# Altium schematic sheet 300_AUX.SchDoc
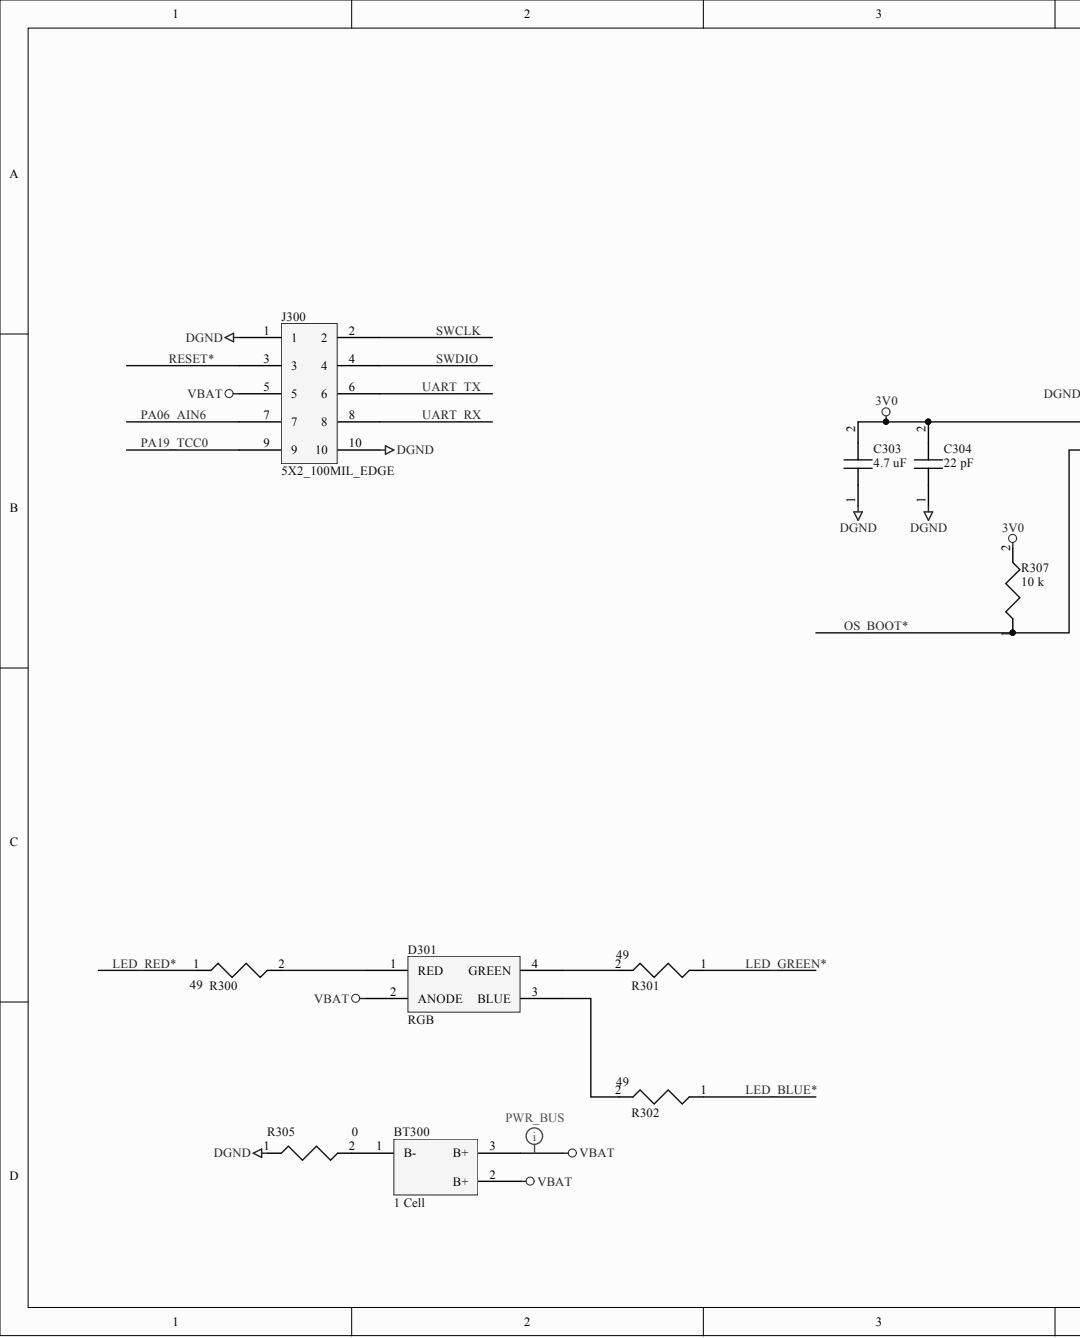
[diagram p0: 300_AUX.SchDoc, left]
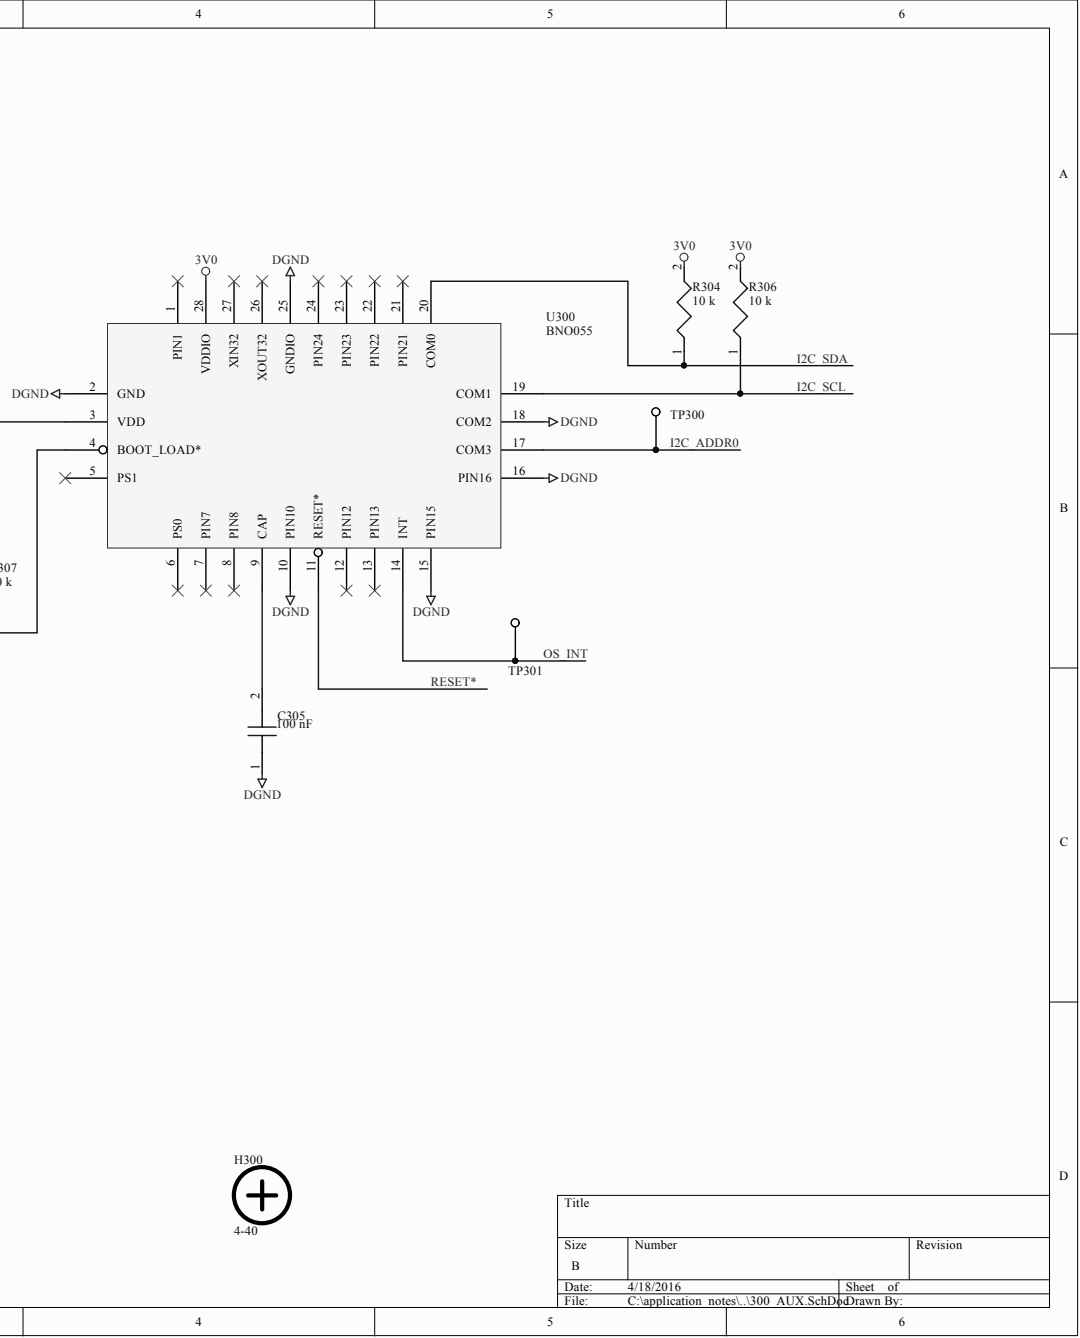
[diagram p1: 300_AUX.SchDoc, right]

## Parts
BT300  1 Cell  [CR2032_HOLDER]
C303  4.7 uF  [CAPC1608N]
C304  22 pF  [CAPC1005N]
C305  100 nF  [CAPC1005N]
D301  RGB  [CLVA-FKB]
H300  4-40  [SCREW_4-40]  (no BOM)
J300  5X2_100MIL_EDGE  [5X2_100_EDGE]
R300  49  [RESC1005N]
R301  49  [RESC1005N]
R302  49  [RESC1005N]
R304  10 k  [RESC1005N]
R305  0  [RESC1005N]  (value not drawn)
R306  10 k  [RESC1005N]
R307  10 k  [RESC1005N]
TP300  TEST_POINT  [TEST_POINT]  (value not drawn)  (no BOM)
TP301  TEST_POINT  [TEST_POINT]  (value not drawn)  (no BOM)
U300  BNO055  [BNO055]

## Nets
3V0: C303.2 C304.2 R304.2 R306.2 R307.2 U300.3(VDD) U300.28(VDDIO)
DGND: C303.1 C304.1 C305.1 J300.1 J300.10 R305.1 U300.2(GND) U300.10(PIN10) U300.15(PIN15) U300.16(PIN16) U300.18(COM2) U300.25(GNDIO)
VBAT: BT300.2 BT300.3 D301.2(ANODE) J300.5
I2C_ADDR0: TP300.1 U300.17(COM3)
I2C_SCL: R306.1 U300.19(COM1)
I2C_SDA: R304.1 U300.20(COM0)
LED_BLUE*: R302.1
LED_GREEN*: R301.1
LED_RED*: R300.1
OS_BOOT*: R307.1 U300.4(BOOT_LOAD*)
OS_INT: TP301.1 U300.14(INT)
PA06_AIN6: J300.7
PA19_TCC0: J300.9
RESET*: J300.3 U300.11(RESET*)
SWCLK: J300.2
SWDIO: J300.4
UART_RX: J300.8
UART_TX: J300.6
NetBT300_1: BT300.1 R305.2
NetC305_2: C305.2 U300.9(CAP)
NetD301_1: D301.1(RED) R300.2
NetD301_3: D301.3(BLUE) R302.2
NetD301_4: D301.4(GREEN) R301.2

Not connected: U300 1(PIN1) 5(PS1) 6(PS0) 7(PIN7) 8(PIN8) 12(PIN12) 13(PIN13) 21(PIN21) 22(PIN22) 23(PIN23) 24(PIN24) 26(XOUT32) 27(XIN32)

## Library data of the parts
BT300 = CR2032_HOLDER: HOLDER BATTERY T/H CR2032; MPN: 120591-1; MFG: TE Connectivity; Vendor: Digikey; VPN: A99328-ND; Component Kind: Standard; Value: 1 Cell; Library Name: fiddly_bits.SchLib
C303 = C_0603: CAP CER 4.7UF 6.3V 10% X5R 0603; MPN: GRM188R60J475KE19D; MFG: MURATA; VENDOR: DIGIKEY; VPN: 490-3297-1-ND; Component Kind: Standard; Library Name: rlc.SchLib; VALUE: 4.7 uF
C304 = C_0402: CAP CER 22PF 50V 1% NP0 0402; MPN: GRM1555C1H220FA01D; MFG: MURATA; VENDOR: DIGIKEY; VPN: 490-8589-1-ND; Component Kind: Standard; Library Name: rlc.SchLib; VALUE: 22 pF
C305 = C_0402: CAP CER 0.1UF 10V 10% X5R 0402; MPN: GRM155R61A104KA01D; MFG: MURATA; VENDOR: DIGIKEY; VPN: 490-1318-1-ND; Component Kind: Standard; Library Name: rlc.SchLib; VALUE: 100 nF
D301 = CLV1A-FKB: LED RGB DIFFUSED 4PLCC SMD; MPN: CLV1A-FKB-CK1N1G1BB7R4S3; MFG: Cree; Vendor: Digikey; VPN: CLV1A-FKB-CK1N1G1BB7R4S3CT-ND; Component Kind: Standard; Library Name: clv1a-fkb.SchLib; Value: RGB
H300 = SCREW_4-40: Machine Screw 4-40; MFG: GENERIC; VALUE: 4-40; Library Name: fiddly_bits.SchLib
J300 = 5X2_100MIL_EDGE: CONN HEADER 10POS .110' SGL GOLD; MPN: TSW-110-07-L-S; MFG: Samtech; VALUE: 5X2 Edge Mounted; VENDOR: Digikey; VPN: SAM1031-10-ND; Component Kind: Standard; Library Name: headers.SchLib
R300-R302 R304-R307 = R_0402: R 0402; MFG: GENERIC; Component Kind: Standard; Library Name: rlc.SchLib
  R300-R302: VALUE: 49
  R304 R306 R307: VALUE: 10 k
  R305: VALUE: 0
TP300 TP301 = TEST_POINT: Test Point 40 mil dia; Library Name: fiddly_bits.SchLib
U300 = BNO055: IMUs - Inertial Measurement Units Absolute Orientation 9-Axis Sensor; MFG: Bocsh; VENDOR: Mouser; VPN: 262-BNO055; Component Kind: Standard; Library Name: bno055.SchLib; MPN: BNO055; VALUE: 9-Axis
